# Altium schematic sheet Preamp&Filter.SchDoc
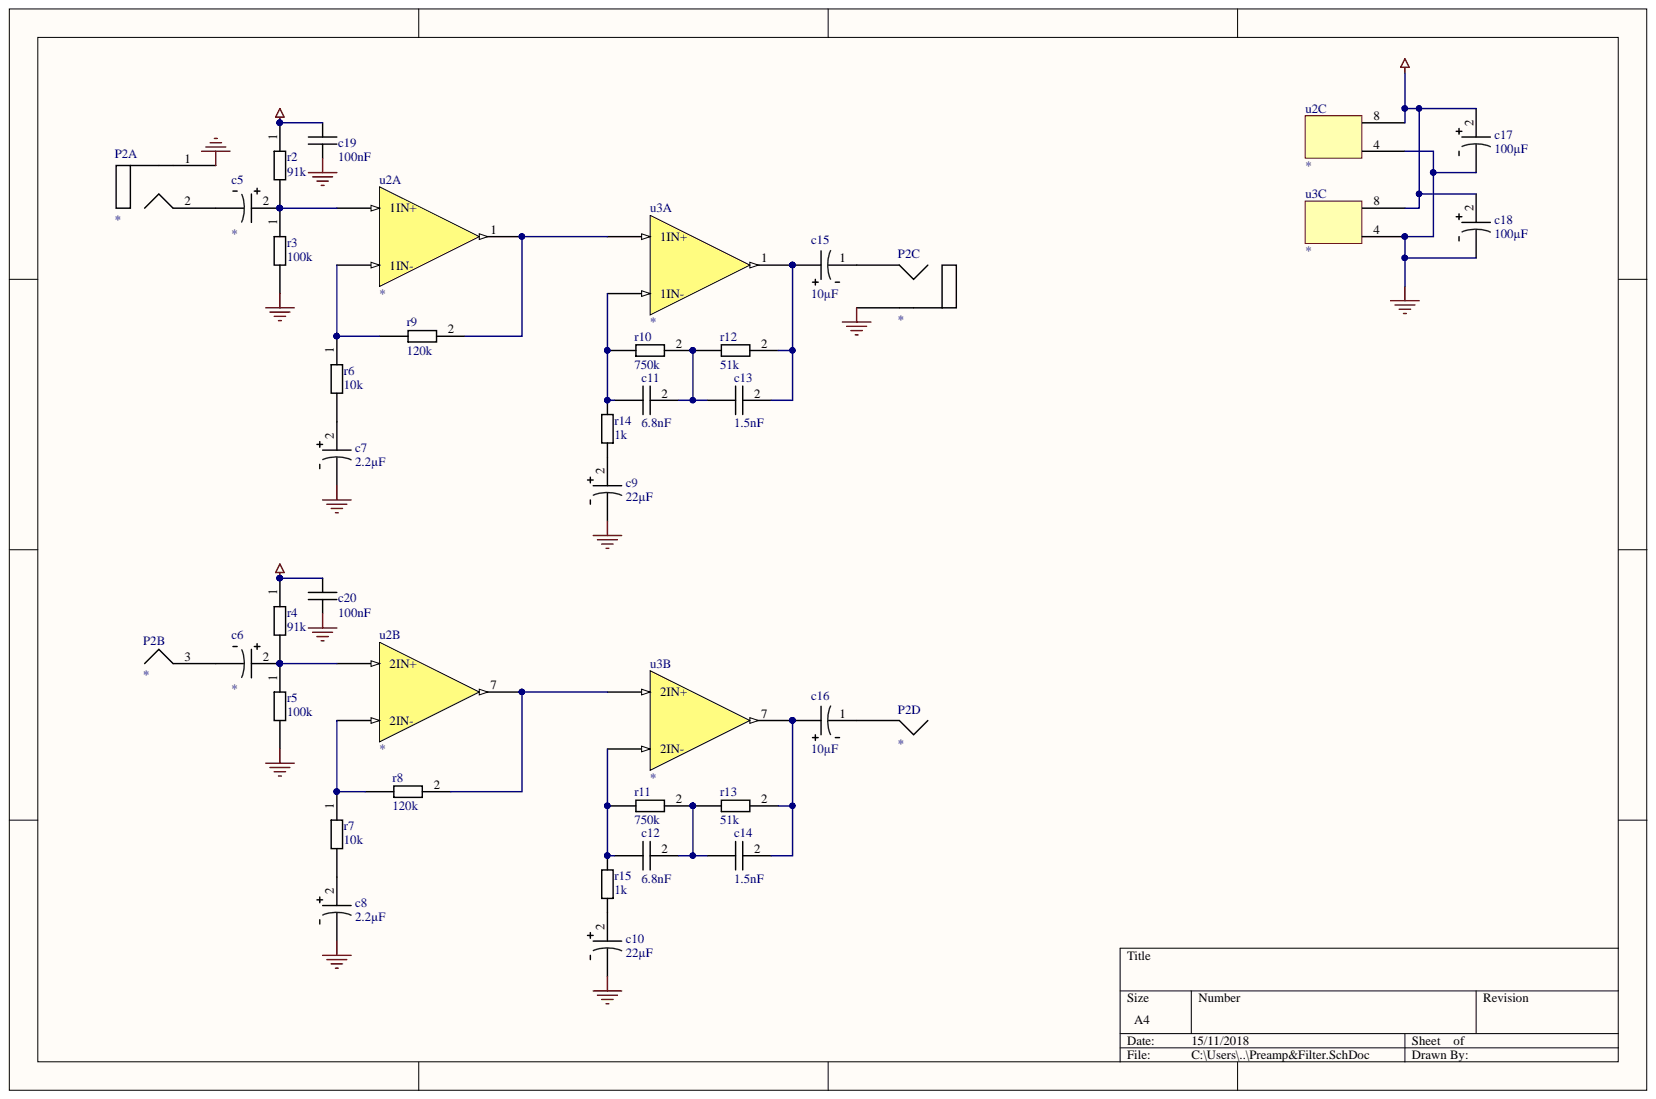
[diagram p0: Preamp&Filter.SchDoc]

## Parts
c5  (no value)  [Elco-B]
c6  (no value)  [Elco-B]
c7  2.2µF  [Elco-B]
c8  2.2µF  [Elco-B]
c9  22µF  [Elco-D]
c10  22µF  [Elco-D]
c11  6.8nF  [0805]
c12  6.8nF  [0805]
c13  1.5nF  [0805]
c14  1.5nF  [0805]
c15  10µF  [Elco-C]
c16  10µF  [Elco-C]
c17  100µF  [Elco-D]
c18  100µF  [Elco-D]
c19  100nF  [0805]
c20  100nF  [0805]
P2  (no value)  [RCA 4-way]  (parts A,B,C,D)
r2  91k  [0805]
r3  100k  [0805]
r4  91k  [0805]
r5  100k  [0805]
r6  10k  [0805]
r7  10k  [0805]
r8  120k  [0805]
r9  120k  [0805]
r10  750k  [0805]
r11  750k  [0805]
r12  51k  [0805]
r13  51k  [0805]
r14  1k  [0805]
r15  1k  [0805]
u2  (no value)  [R-PDSO-G8-P127d620x530]  (parts A,B,C)
u3  (no value)  [R-PDSO-G8-P127d620x530]  (parts A,B,C)

## Nets
GND: c7.1 c8.1 c9.1 c10.1 c17.1 c18.1 c19.1 c20.1 P2.1 P2.4 r3.2 r5.2 u2.4 u3.4
VCC: c17.2 c18.2 c19.2 c20.2 r2.1 r4.1 u2.8 u3.8
Netc5_1: c5.1 P2.2
Netc5_2: c5.2 r2.2 r3.1 u2.3(1IN+)
Netc6_1: c6.1 P2.3
Netc6_2: c6.2 r4.2 r5.1 u2.5(2IN+)
Netc7_2: c7.2 r6.2
Netc8_2: c8.2 r7.2
Netc9_2: c9.2 r14.1
Netc10_2: c10.2 r15.1
Netc11_1: c11.1 r10.1 r14.2 u3.2(1IN-)
Netc11_2: c11.2 c13.1 r10.2 r12.1
Netc12_1: c12.1 r11.1 r15.2 u3.6(2IN-)
Netc12_2: c12.2 c14.1 r11.2 r13.1
Netc13_2: c13.2 c15.2 r12.2 u3.1
Netc14_2: c14.2 c16.2 r13.2 u3.7
Netc15_1: c15.1 P2.5
Netc16_1: c16.1 P2.6
Netr6_1: r6.1 r9.1 u2.2(1IN-)
Netr7_1: r7.1 r8.1 u2.6(2IN-)
Netr8_2: r8.2 u2.7 u3.5(2IN+)
Netr9_2: r9.2 u2.1 u3.3(1IN+)

## Library data of the parts
c5-c8 = Elco B: Component Kind: Standard; Library Name: Panasonic.SchLib
c9 c10 c17 c18 = Elco D: Elco size D 6.3x5.4; Component Kind: Standard; Library Name: Panasonic.SchLib
c11-c14 = 0805 Capacitor: 0805 Capacitor Package; Component Kind: Standard; Library Name: Generic R-C.SchLib
c15 c16 = Elco C: Component Kind: Standard; Library Name: Panasonic.SchLib
c19 c20 = 0805 Capacitor: ...F; Component Kind: Standard; Library Name: Generic R-C.SchLib
P2 = PSG01551: 4-way RCA connector; Component Kind: Standard; Library Name: Pro-Signal.SchLib
r2-r13 = 0805 Resistor: 0805 Resistor package; Component Kind: Standard; Library Name: Generic R-C.SchLib
r14 r15 = 0805 Resistor: ...R; Component Kind: Standard; Library Name: Generic R-C.SchLib
u2 = NE5532x: Dual Low-Noise OpAmp; Component Kind: Standard; Library Name: Texas Instruments.SchLib
u3 = TL027x: Low-Noise JFET-input OpAmp; Component Kind: Standard; Library Name: Texas Instruments.SchLib
